# Altium schematic sheet SCH - ROBOTIC ARM.SchDoc
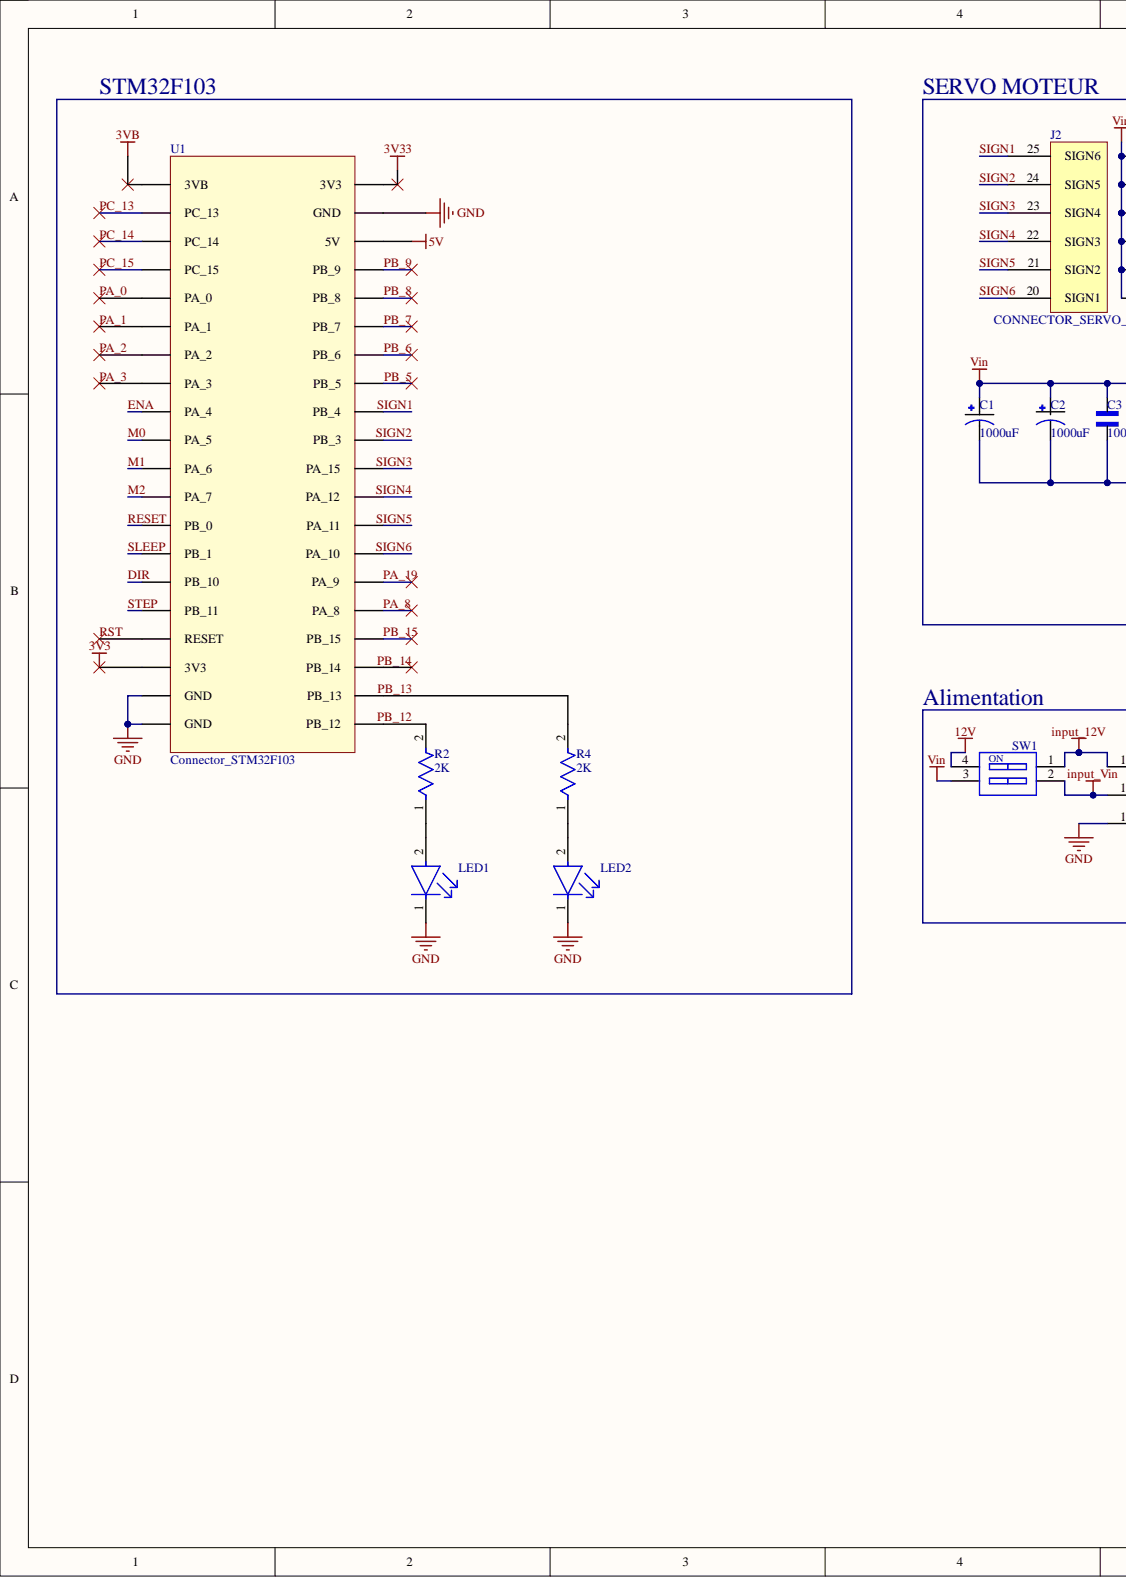
[diagram p0: SCH - ROBOTIC ARM.SchDoc, left]
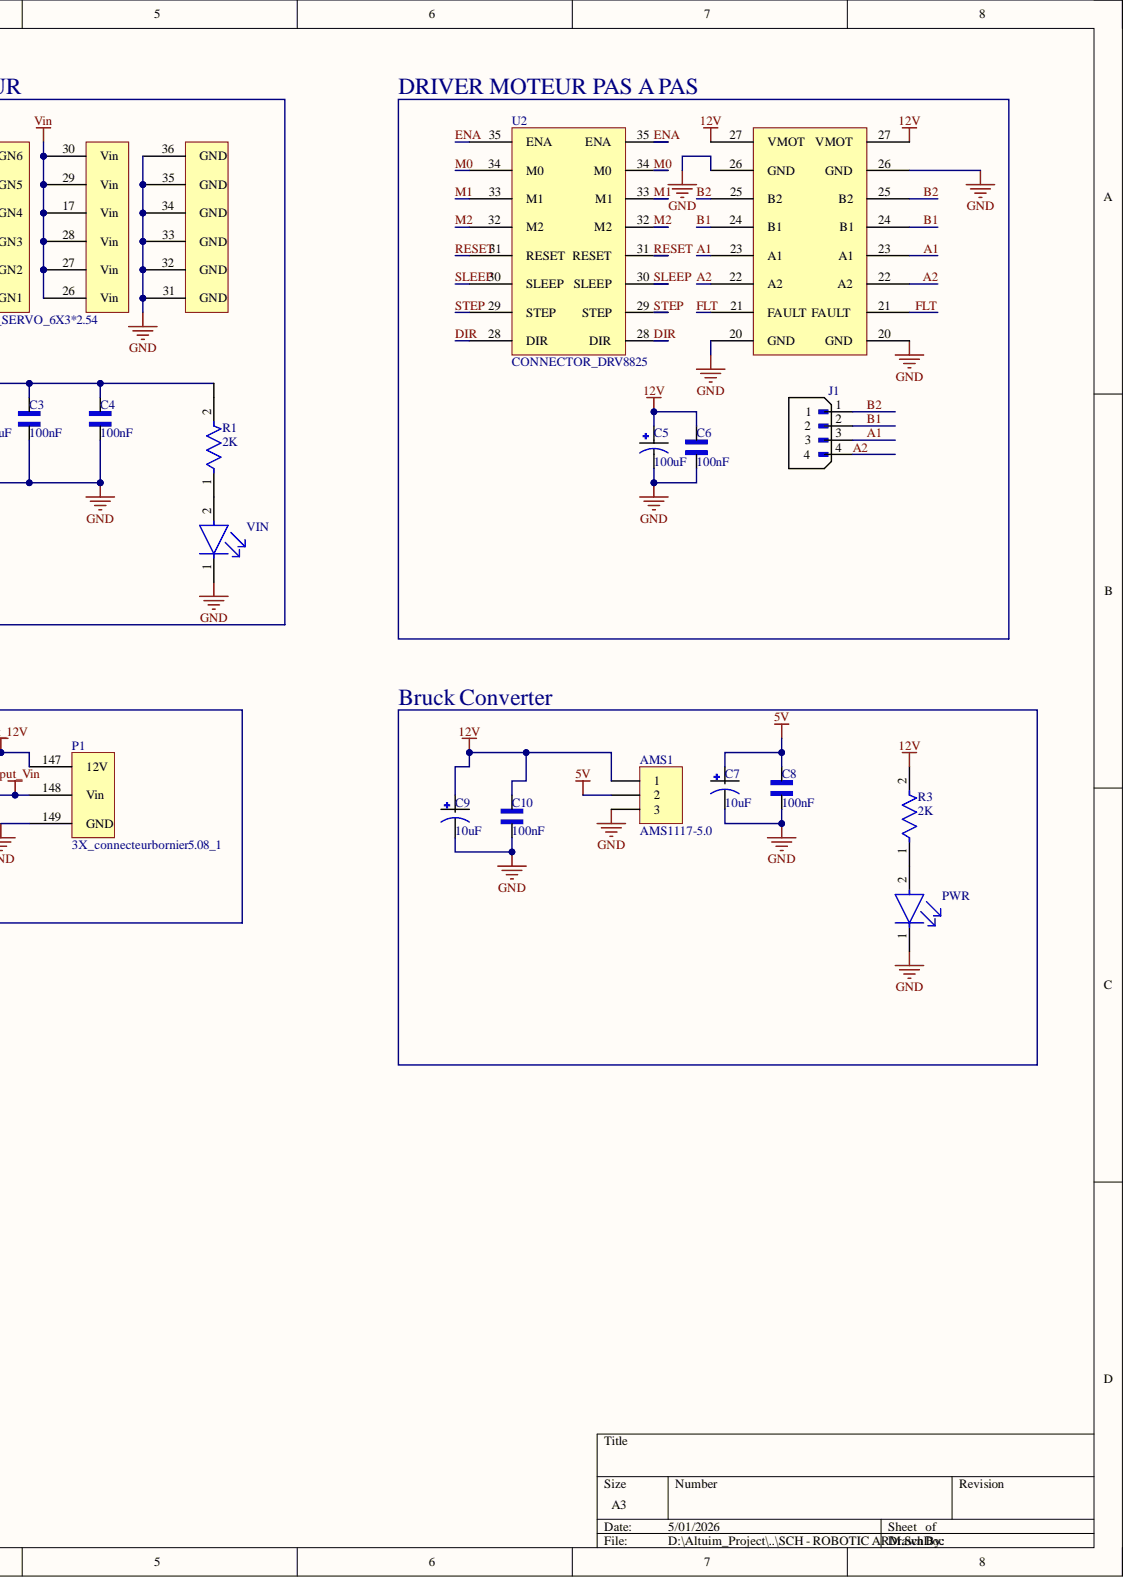
[diagram p1: SCH - ROBOTIC ARM.SchDoc, right]

## Parts
AMS1  AMS1117-5.0  [HDR1X3]
C1  1000uF  [*CAPPRD500W60D1000H1600_ECA1CM102(Primary)]
C2  1000uF  [*CAPPRD200W50D550H1250]
C3  100nF  [*CAPRR508W50L508T317H508]
C4  100nF  [*CAPRR508W50L508T317H508]
C5  100uF  [*CAPPRD500W60D1000H1600_ECA1CM102(Primary)]
C6  100nF  [*CAPRR508W50L508T317H508]
C7  10uF  [*CAPPRD200W50D550H1250]
C8  100nF  [*CAPRR508W50L508T317H508]
C9  10uF  [*CAPPRD200W50D550H1250]
C10  100nF  [*CAPPRD200W50D550H1250]
J1  B4B-XH-A(LF)(SN)  [*JST_B4B-XH-A(LF)(SN)]  (value not drawn)
J2  CONNECTOR_SERVO_6X3*2.54  [CONNECTOR_SERVO_6X3*2.54]
LED1  LED1  [FP-VSLB3940-MFG]
LED2  LED1  [FP-VSLB3940-MFG]  (value not drawn)
P1  3X_connecteurbornier5.08_1  [3X_connecteur_bornier_5.08]
PWR  LED1  [FP-VSLB3940-MFG]  (value not drawn)
R1  2K  [FP-CMF50-0]
R2  2K  [FP-CMF50-0]
R3  2K  [FP-CMF50-0]
R4  2K  [FP-CMF50-0]
SW1  418117170902  [418117170902]  (value not drawn)
U1  Connector_STM32F103  [Connector_STM32F103]
U2  CONNECTOR_DRV8825  [CONNECTOR_DRV8825]
VIN  LED1  [FP-VSLB3940-MFG]  (value not drawn)

## Nets
3V3: U1.143(3V3)
3V33: U1.105(3V3)
3VB: U1.126(3VB)
5V: AMS1.2 C7.1 C8.1 U1.107(5V)
12V: AMS1.1 C5.P C6.1 C9.1 C10.1 R3.2 SW1.4 U2.27
GND: AMS1.3 C1.N C2.2 C3.2 C4.2 C5.N C6.2 C7.2 C8.2 C9.2 C10.2 J2.31 J2.32 J2.33 J2.34 J2.35 J2.36 LED1.1 LED2.1 P1.149 PWR.1 U1.106(GND) U1.144(GND) U1.145(GND) U2.20 U2.26 VIN.1
input_12V: P1.147 SW1.1
input_Vin: P1.148 SW1.2
Vin: C1.P C2.1 C3.1 C4.1 J2.17(Vin) J2.26(Vin) J2.27(Vin) J2.28(Vin) J2.29(Vin) J2.30(Vin) R1.2 SW1.3
A1: J1.3 U2.23
A2: J1.4 U2.22
B1: J1.2 U2.24(B1)
B2: J1.1 U2.25
DIR: U1.140(PB_10) U2.28(DIR)
ENA: U1.134(PA_4) U2.35(ENA)
FLT: U2.21
M0: U1.135(PA_5) U2.34(M0)
M1: U1.136(PA_6) U2.33(M1)
M2: U1.137(PA_7) U2.32(M2)
PA_0: U1.130(PA_0)
PA_1: U1.131(PA_1)
PA_2: U1.132(PA_2)
PA_3: U1.133(PA_3)
PA_8: U1.120(PA_8)
PA_19: U1.119(PA_9)
PB_5: U1.112(PB_5)
PB_6: U1.111(PB_6)
PB_7: U1.110(PB_7)
PB_8: U1.109(PB_8)
PB_9: U1.108(PB_9)
PB_12: R2.2 U1.124(PB_12)
PB_13: R4.2 U1.123(PB_13)
PB_14: U1.122(PB_14)
PB_15: U1.121(PB_15)
PC_13: U1.127(PC_13)
PC_14: U1.128(PC_14)
PC_15: U1.129(PC_15)
RESET: U1.138(PB_0) U2.31(RESET)
RST: U1.142(RESET)
SIGN1: J2.25(SIGN6) U1.113(PB_4)
SIGN2: J2.24(SIGN5) U1.114(PB_3)
SIGN3: J2.23(SIGN4) U1.115(PA_15)
SIGN4: J2.22(SIGN3) U1.116(PA_12)
SIGN5: J2.21(SIGN2) U1.117(PA_11)
SIGN6: J2.20(SIGN1) U1.118(PA_10)
SLEEP: U1.139(PB_1) U2.30(SLEEP)
STEP: U1.141(PB_11) U2.29(STEP)
NetLED1_2: LED1.2 R2.1
NetLED2_2: LED2.2 R4.1
NetPWR_2: PWR.2 R3.1
NetR1_1: R1.1 VIN.2

## Library data of the parts
AMS1 = Header 3: Header, 3-Pin; Component Kind: Standard; LatestRevisionDate: 17-Jul-2002; LatestRevisionNote: Re-released for DXP Platform.; Library Name: Miscellaneous Connectors.IntLib; Signal Integrity: Connector; Publisher: Altium Limited
C1 C5 = ECA1CM102: 1000ÂµF 16V Aluminum Electrolytic Capacitors Radial, Can 2000 Hrs @ 85Â°C; MF: Panasonic; Availability: In Stock; Check_prices: https://www.snapeda.com/parts/ECA1CM102/Panasonic/view-part/?ref=eda; Component Kind: Standard; Library Name: Schlib1.SchLib; MP: ECA1CM102; Package: RADIAL-2 Panasonic; Price: None; SnapEDA_Link: https://www.snapeda.com/parts/ECA1CM102/Panasonic/view-part/?ref=snap
C2 C7 C9 = UVR1E100MDD: 10 ÂµF 25 V Aluminum Electrolytic Capacitors Radial, Can - 2000 Hrs @ 85Â°C; MF: Nichicon; Availability: In Stock; Check_prices: https://www.snapeda.com/parts/UVR1E100MDD/Nichicon/view-part/?ref=eda; Component Kind: Standard; Library Name: Schlib1.SchLib; MP: UVR1E100MDD; Package: RADIAL-2 NICHICON; Price: None; SnapEDA_Link: https://www.snapeda.com/parts/UVR1E100MDD/Nichicon/view-part/?ref=snap
C3 C4 C6 C8 C10 = SR215E104MARTR1: SR Series 5.08x5.08 mm 100 nF 50 V Â±20% Tol. Z5U Radial Lead/SkyCapÂ®; MF: AVX; Availability: In Stock; Check_prices: https://www.snapeda.com/parts/SR215E104MARTR1/AVX/view-part/?ref=eda; Component Kind: Standard; Library Name: Schlib1.SchLib; MP: SR215E104MARTR1; Package: Radial AVX Interconnect / Elco; Price: None; SnapEDA_Link: https://www.snapeda.com/parts/SR215E104MARTR1/AVX/view-part/?ref=snap
J1 = B4B-XH-A(LF)(SN): HEADER, VERTICAL, 4WAY; Pitch Spacing: 2.5mm; No. of Contacts: 4Contacts; Gender: Header; Product Range: XH Series; Contact Termination Type: Through Hole; No. of Rows: 1Rows; Contact Plating: Tin Plated Contacts; Contact Material: Brass; SVHC: No SVHC (17-Dec-2015); Contact Resistance: 10mohm; Current Rating: 3A; Insulation Resistance: 1000Mohm; Operating Temperature Max: 85Â°C; Operating Temperature Min: -25Â°C; Voltage Rating VAC: 250V; Wire Size AWG Max: 22AWG; Wire Size AWG Min: 30AWG; Withstand Voltage: 1000V; MF: JST; Availability: In Stock; Check_prices: https://www.snapeda.com/parts/B4B-XH-A%20%20(LF)(SN)/JST/view-part/?ref=eda; Component Kind: Standard; Library Name: Schlib1.SchLib; MP: B4B-XH-A  (LF)(SN); Package: None; Price: None; SnapEDA_Link: https://www.snapeda.com/parts/B4B-XH-A%20%20(LF)(SN)/JST/view-part/?ref=snap
LED1 LED2 PWR VIN = CMP-00030-18785862-1: VSLB3940 940 nm 100 mA ±22° Through Hole High Speed Infrared Emitting Diode - T-1; Fall Time: 15ns; Forward Current: 100mA; Forward Voltage: 1.35V; Lens Style: Circular, Diffused, Round; Max Power Dissipation: 160mW; Number of Elements: 1; Peak Wavelength: 940nm; Reverse Voltage: 5V; Viewing Angle: 44°; Case/Package: T; Component Kind: Standard; Depth: 3.2mm; Lead Free: Lead Free; Length: 3.2mm; Library Name: Altium Content Vault; Max Operating Temperature: 85°C; Min Operating Temperature: -25°C; Mount: Through Hole; NexarPartId: 18785862; Number of LEDs: 1; Number of Pins: 2; Orientation: Top View; Packaging: Bulk; Power Dissipation: 160mW; Power Rating: 160mW; Radiation Hardening: No; REACH SVHC: Unknown; Rise Time: 15ns; RoHS: Compliant; Test Current: 100mA; Wavelength: 940nm
R1-R4 = CMP-00030-43762971-1: RN50C9532FB14 RN50 Series 0.05 W 95.3 kOhm ± 1% ±50 ppm/°C Axial Metal Film Resistor; Lead Diameter: 410µm; Max Power Dissipation: 50mW; Resistance: 95.3kΩ; Voltage Rating: 200V; Case/Package: Axial; Component Kind: Standard; Composition: Metal Film; Contact Plating: Lead, Tin; Diameter: 1.65mm; Features: Flame Retardant Coating, Military; Height: 2.03mm; Lead Free: Contains Lead; Length: 3.81mm; Library Name: Altium Content Vault; Max Operating Temperature: 175°C; Military Standard: MIL-R-10509; Min Operating Temperature: -65°C; Mount: Through Hole; NexarPartId: 43762971; Number of Terminations: 2; Packaging: Bulk; Power Rating: 50mW; Radiation Hardening: No; RoHS: Non-Compliant; Temperature Coefficient: 50ppm/°C; Termination: Axial; Tolerance: 1%; Width: 1.651mm
SW1 = CMP-1434-00002-3: Dip Switch, 0.025A, 24Vdc, 2 Pos, Tht Rohs Compliant: Yes; Body Material: PBT, Polybutylene; Current Rating: 25mA; Electrical Life: 2kcycles; Insulation Resistance: 100MΩ; Number of Positions: 2; Pitch: 2.54mm; Throw Configuration: SPST; Voltage Rating: 24V; Washable: No; Component Kind: Standard; Contact Plating: Gold; Length: 6.08mm; Library Name: Altium Content Vault; Max Operating Temperature: 85°C; Mechanical Life: 2kcycles; Min Operating Temperature: -40°C; RoHS: Compliant; Termination: PC Pin; Voltage Rating (DC): 24V
J2 = CONNECTOR_SERVO_6X3*2.54: Component Kind: Standard; Library Name: Schlib1.SchLib
P1 = 3X_connecteurbornier5.08_1: Component Kind: Standard; Library Name: Schlib1.SchLib
U1 = Connector_STM32F103: Component Kind: Standard; Library Name: Schlib1.SchLib
U2 = CONNECTOR_DRV8825: Component Kind: Standard; Library Name: Schlib1.SchLib
